# Altium schematic sheet Internal.SchDoc
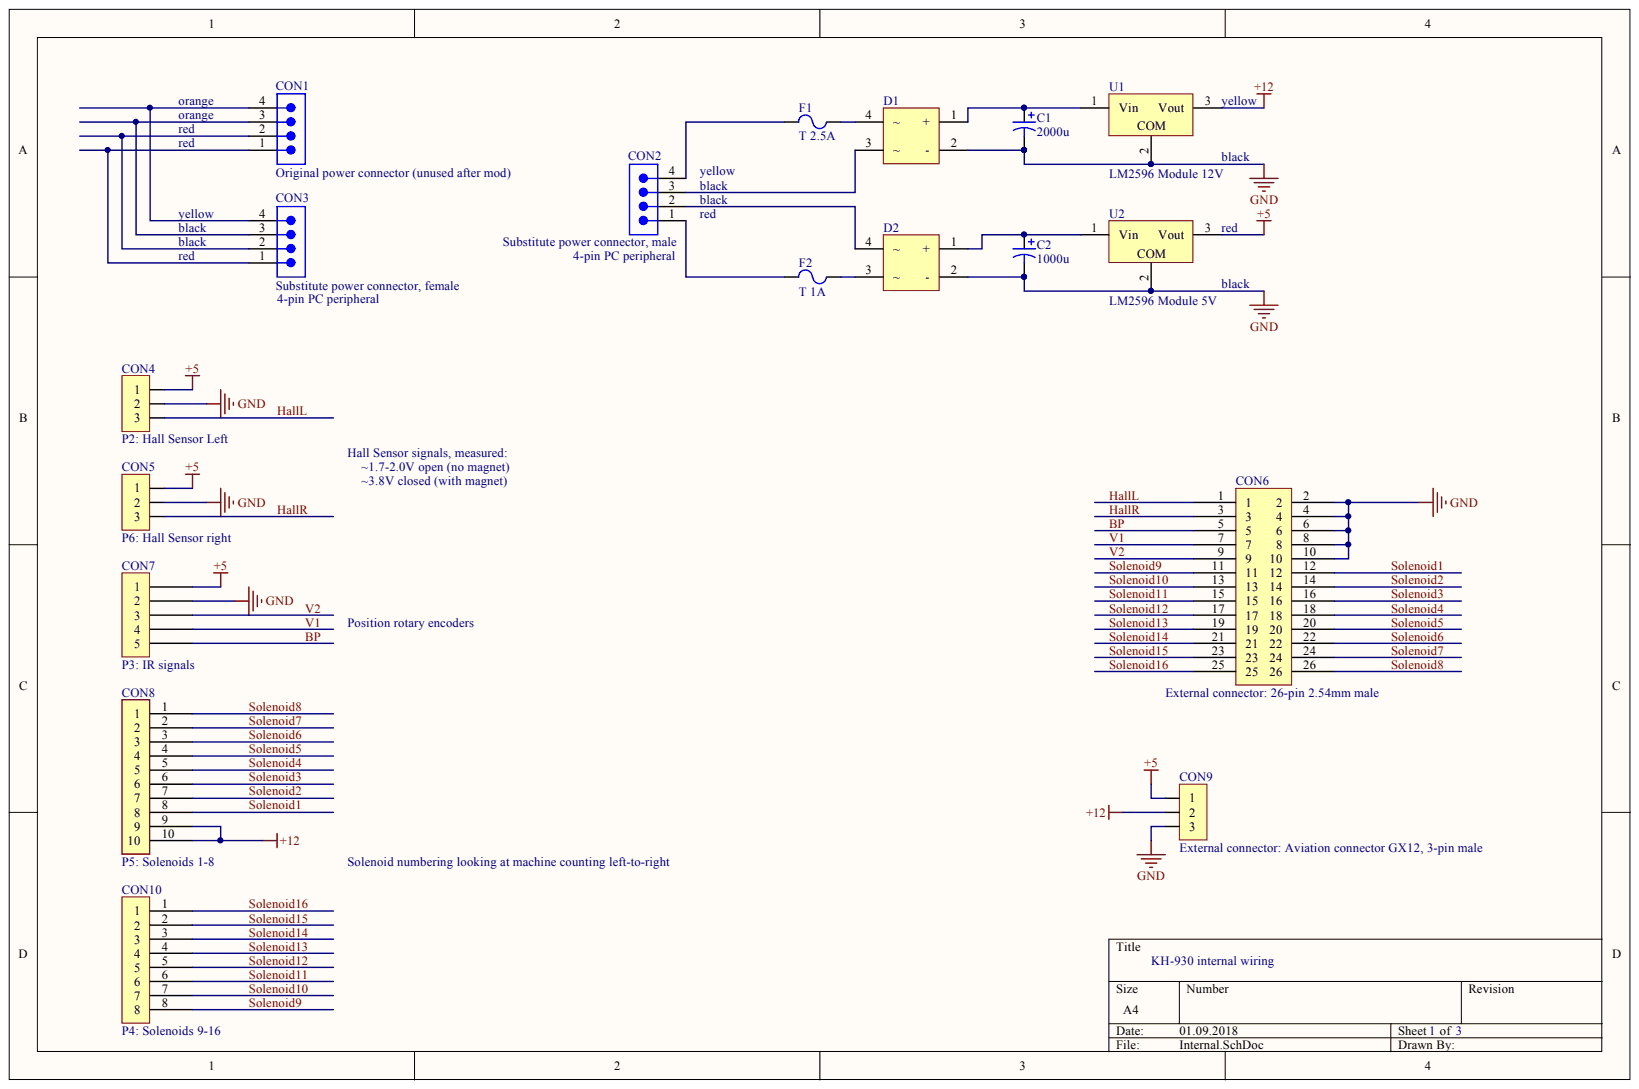
[diagram p0: Internal.SchDoc]

## Parts
C1  2000u  [Capacitor]
C2  1000u  [Capacitor]
CON1  Original power connector (unused after mod)  [PCB-kyzvxp2nvgyimcljxmwn-1]
CON2  Substitute power connector, male  [PCB-kyzvxp2nvgyimcljxmwn-1]
CON3  Substitute power connector, female  [PCB-kyzvxp2nvgyimcljxmwn-1]
CON4  P2: Hall Sensor Left  [PCBComponent_1 - duplicate]
CON5  P6: Hall Sensor right  [PCBComponent_1 - duplicate]
CON6  External connector: 26-pin 2.54mm male  [PCB-anyg1stvhu39ted5u9rh-1]
CON7  P3: IR signals  [PCB-3jmh84ae1tdhvzuyiijn-1]
CON8  P5: Solenoids 1-8  [PCB-64iporpol8hwe85n8w39-1]
CON9  External connector: Aviation connector GX12, 3-pin male  [PCBComponent_1 - duplicate]
CON10  P4: Solenoids 9-16  [PCB-d7bbq60qdmx65uqpgpu2-1]
D1  Vishay 2W10G-E4/51  [PCB-7bd7hhtq4w25gwsfr2q7-1]  (value not drawn)
D2  Vishay 2W10G-E4/51  [PCB-7bd7hhtq4w25gwsfr2q7-1]  (value not drawn)
F1  T 2.5A  [PCB-5qxyjofu6a40hj5s6qdl-1]
F2  T 1A  [PCB-5qxyjofu6a40hj5s6qdl-1]
U1  LM2596 Module 12V  [PCB-eadz4r9y6y9467z7yr2w-1]
U2  LM2596 Module 5V  [PCB-eadz4r9y6y9467z7yr2w-1]

## Nets
+5: CON4.1 CON5.1 CON7.1 CON9.1 U2.3
+12: CON8.9 CON8.10 CON9.2 U1.3
GND: C1.2 C2.2 CON4.2 CON5.2 CON6.2 CON6.4 CON6.6 CON6.8 CON6.10 CON7.2 CON9.3 D1.2(-) D2.2(-) U1.2 U2.2
BP: CON6.5 CON7.5
HALLL: CON4.3 CON6.1
HALLR: CON5.3 CON6.3
SOLENOID1: CON6.12 CON8.8
SOLENOID2: CON6.14 CON8.7
SOLENOID3: CON6.16 CON8.6
SOLENOID4: CON6.18 CON8.5
SOLENOID5: CON6.20 CON8.4
SOLENOID6: CON6.22 CON8.3
SOLENOID7: CON6.24 CON8.2
SOLENOID8: CON6.26 CON8.1
SOLENOID9: CON6.11 CON10.8
SOLENOID10: CON6.13 CON10.7
SOLENOID11: CON6.15 CON10.6
SOLENOID12: CON6.17 CON10.5
SOLENOID13: CON6.19 CON10.4
SOLENOID14: CON6.21 CON10.3
SOLENOID15: CON6.23 CON10.2
SOLENOID16: CON6.25 CON10.1
V1: CON6.7 CON7.4
V2: CON6.9 CON7.3
NetC1_1: C1.1 D1.1(+) U1.1
NetC2_1: C2.1 D2.1(+) U2.1
NetCON1_1: CON1.1 CON3.1
NetCON1_2: CON1.2 CON3.2
NetCON1_3: CON1.3 CON3.3
NetCON1_4: CON1.4 CON3.4
NetCON2_1: CON2.1 F2.1
NetCON2_2: CON2.2 D2.4(~)
NetCON2_3: CON2.3 D1.3(~)
NetCON2_4: CON2.4 F1.1
NetD1_4: D1.4(~) F1.2
NetD2_3: D2.3(~) F2.2

## Library data of the parts
C1 C2 = ECA-1EM101: Cap Aluminum 100uF 25V 20% (6.3 X 11.2mm) Radial Aluminum Cylindrical Can 2.5mm 180mA 2000 hr 85°C Bulk; Capacitance: 100 µF; Lead-Free Status: Lead Free; Voltage Rating (DC): 25.0 V; Component Kind: Standard; Library Name: Octopart library; Manufacturer Part Number: ECA-1EM101; Mounting Style: Through Hole; Number of Pins: 2; Octopart URL: https://octopart.com/eca-1em101-panasonic-55558322; Operating Temperature: 85.0 °C (max); REACH SVHC Compliance: No SVHC; Size-Height: 11.2 mm
CON1-CON3 = 47053-1000: 2.54mm Pitch Vertical Header, with Friction Lock, 4 Circuits, PC Tail Length: 3.50mm; Component Kind: Standard; Library Name: Octopart library; Lifecycle Status: Not Listed by Manufacturer; OctopartID: d93b10f8176306fc; Octopart URL: https://octopart.com/0470531000-molex-13232958; RoHS: Compliant
CON4 CON5 CON9 = CMP-c3e20a8fd0141c25-2: 2.54mm (.100") Pitch C-Grid III Header, Single Row, Vertical, 3 Circuits, Tin (Sn) Plating; Component Kind: Standard; Library Name: CommunityVault
CON6 = 5499922-6: Pin strip + ejector (long) Contact spacing: 2.54 mm Total number of pins: 26 TE Connectivity 1 pc(s); Current Rating: 1.00 A; ELV Compliant: Compliant; Lead-Free Status: Lead Free; Mounting Angle: 180 °; Number of Mating Cycles: 50; Number of Positions: 26; Color: Black; Component Kind: Standard; Contact Plating: Gold, Tin; Flammability Rating: UL 94 V-0; Gender: Male; Housing Color: Black; Housing Material: Thermoplastic; Library Name: Octopart library; Manufacturer: TE Connectivity; Manufacturer Part Number: 5499922-6; Mounting Style: Through Hole, Vertical; Number of Rows: 2; OctopartID: ed6f263ec2da32a8; Octopart URL: http://octopart.com/5499922-6-te+connectivity+%2F+amp-39520979; Orientation: Vertical; Packaging: Tray; Pin Pitch: 2.54 mm; RoHS: Compliant; Size-Height: 13.9 mm; Size-Length: 52.3 mm; Size-Width: 8.53 mm
CON7 = 53261-0571: 1.25mm (.049") Pitch PicoBlade Header, Surface Mount, Right Angle, Lead-free, 5 Circuits; Number of Circuits: 4, 5; Component Kind: Standard; Contact Plating: Tin; Flammability Rating: UL 94 V-0; Library Name: Octopart library; Manufacturer Part Number: 53261-0571
CON8 = 43045-1000: Conn Power HDR 10 POS 3mm Solder RA Thru-Hole 10 Terminal 1 Port Micro-Fit 3.0â¢ Tray; Component Kind: Standard; Library Name: Octopart library
CON10 = 22-28-4083: 8 Positions Header, Unshrouded, Breakaway Connector 0.100" (2.54mm) Through Hole Gold; Manufacturer: Molex, LLC; Number of Positions: 8; Number of Positions Loaded: All; Category: Connectors, Interconnects; Component Kind: Standard; Connector Type: Header, Unshrouded, Breakaway; Contact Finish: Gold; Contact Finish Thickness: 15µin (0.38µm); Contact Mating Length: 0.240" (6.10mm); Contact Type: Male Pin; Cost: 0.67; Library Name: Octopart library; Mounting Type: Through Hole; OctopartID: 83844a46681d5c43; Octopart URL: http://octopart.com/0022284083-molex-13160191; Pitch: 0.100" (2.54mm); Series: KK® 42375; Termination: Solder
D1 D2 = CMP-73e4b86dd4e9ac23-4: 2W10G Series 1000 V 60 A Glass Passivated Single-Phase Bridge Rectifier-WOG; Component Kind: Standard; Library Name: CommunityVault
F1 F2 = CMP-fbd232d8f3272415-9: FUSEHOLDER, PCB MOUNT; Series: 3AG; Fuse Current Rating: 15A; Fuseholder Type: Snap-In; Terminal Type: Pin; Current Rating: 15.0 A; Material: Brass; Component Kind: Standard; Library Name: CommunityVault; Size-Width: 7.50 mm
U1 U2 = R-7812-0.5: R-78-xx Series Single Output 12 V high efficiency DC/DC Converter - SIP-3; Lead-Free Status: Lead Free; Number of Outputs: 1; Output Current: 500 mA; Output Voltage: 12.0 V; Component Kind: Standard; Library Name: Octopart library; Lifecycle Status: Active; Manufacturer Part Number: R-7812-0.5; OctopartID: bfd476aebb226013; Octopart URL: http://octopart.com/r-7812-0.5-recom+power-7348624; REACH SVHC Compliance: No SVHC; RoHS: Compliant; Size-Height: 10.2 mm; Size-Width: 7.55 mm
